# Altium schematic sheet WM_Communication.SchDoc
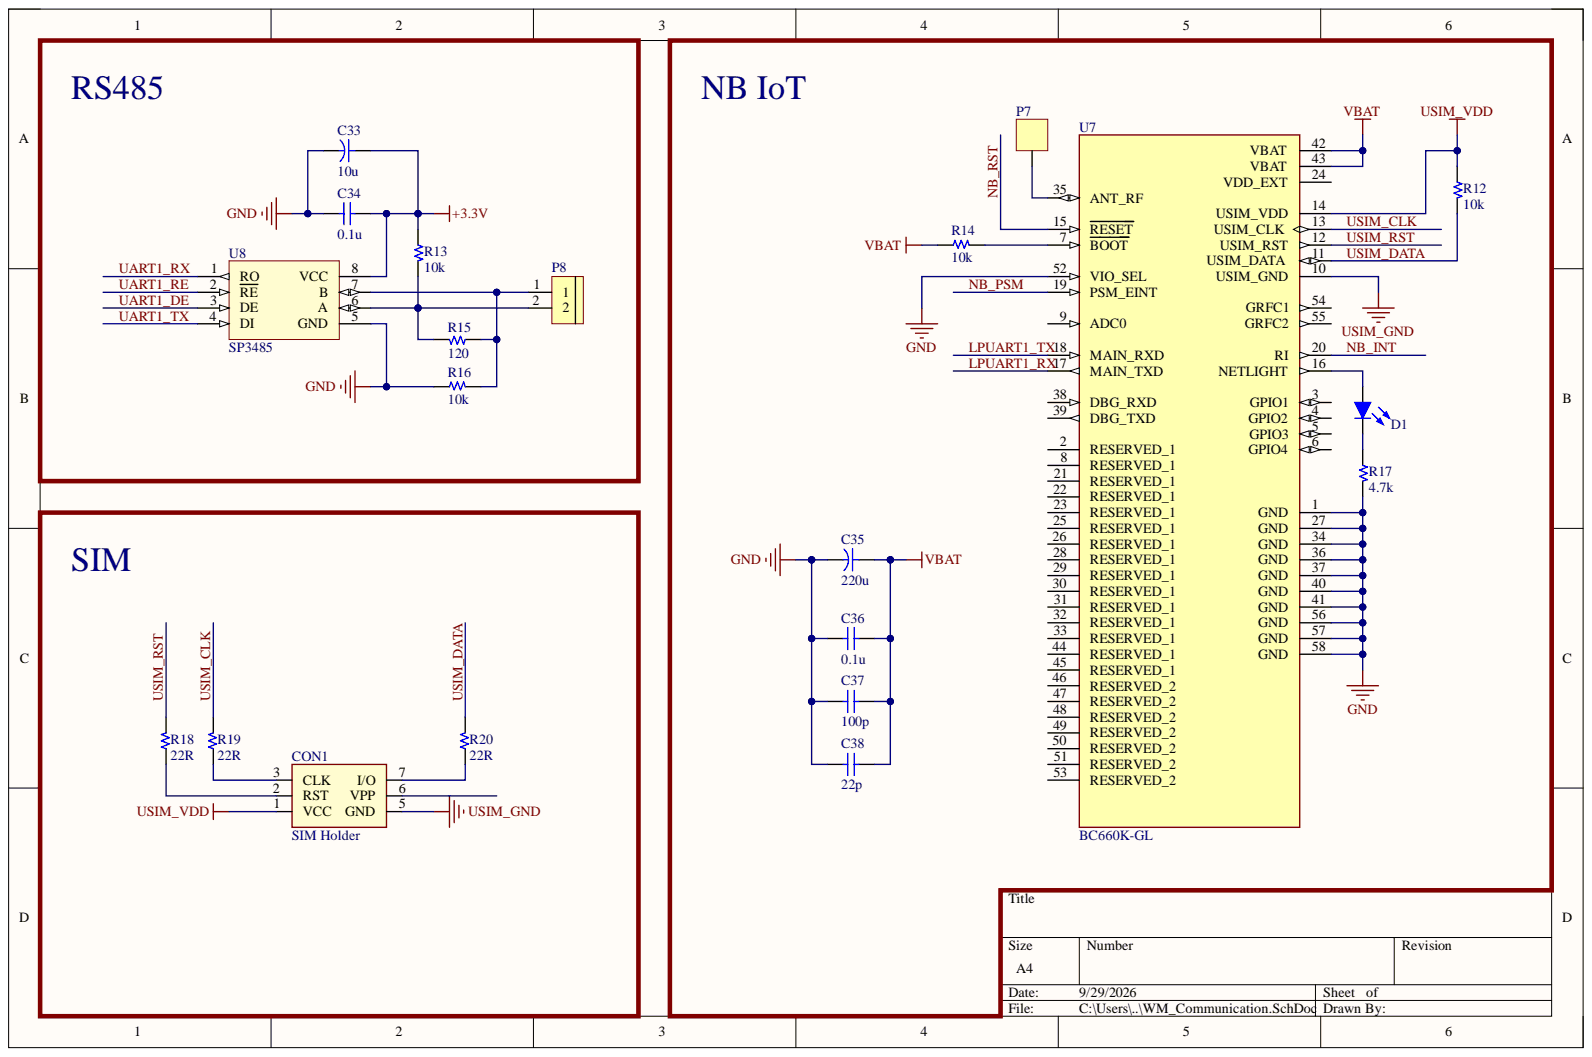
[diagram p0: WM_Communication.SchDoc]

## Parts
C33  10u  [C SMD ALU (B)]
C34  0.1u  [C 0603]
C35  220u  [C SMD ALU (D8)]
C36  0.1u  [C 0603]
C37  100p  [C 0603]
C38  22p  [C 0603]
CON1  SIM Holder  [CON SIM Micro 6P PUSH]
D1  Led  [Led 0603 - green]  (value not drawn)
P7  Header 1  [HDR/Header/1]  (value not drawn)
P8  2EDG5.08  [CON 2 2EDG5.08]  (value not drawn)
R12  10k  [R 0603]
R13  10k  [R 0603]
R14  10k  [R 0603]
R15  120  [R 0603]
R16  10k  [R 0603]
R17  4.7k  [R 0603]
R18  22R  [R 0603]
R19  22R  [R 0603]
R20  22R  [R 0603]
U7  BC660K-GL  [XCVR_BC660K-GL]
U8  SP3485  [SOIC-8]

## Nets
+3.3V: C33.1 C34.2 R13.1 U8.8(VCC)
GND: C33.2 C34.1 C35.2 C36.2 C37.2 C38.2 R16.1 R17.2 U7.1(GND) U7.27(GND) U7.34(GND) U7.36(GND) U7.37(GND) U7.40(GND) U7.41(GND) U7.52(VIO_SEL) U7.56(GND) U7.57(GND) U7.58(GND) U8.5(GND)
USIM_GND: CON1.5(GND) U7.10(USIM_GND)
USIM_VDD: CON1.1(VCC) R12.1 U7.14(USIM_VDD)
VBAT: C35.1 C36.1 C37.1 C38.1 R14.1 U7.42(VBAT) U7.43(VBAT)
LPUART1_RX: U7.17(MAIN_TXD)
LPUART1_TX: U7.18(MAIN_RXD)
NB_INT: U7.20(RI)
NB_PSM: U7.19(PSM_EINT)
NB_RST: U7.15(RESET)
UART1_DE: U8.3(DE)
UART1_RE: U8.2(RE)
UART1_RX: U8.1(RO)
UART1_TX: U8.4(DI)
USIM_CLK: R19.2 U7.13(USIM_CLK)
USIM_DATA: R12.2 R20.2 U7.11(USIM_DATA)
USIM_RST: R18.2 U7.12(USIM_RST)
NetCON1_2: CON1.2(RST) R18.1
NetCON1_3: CON1.3(CLK) R19.1
NetCON1_7: CON1.7(I/O) R20.1
NetD1_1: D1.1 U7.16(NETLIGHT)
NetD1_2: D1.2 R17.1
NetP7_1: P7.1 U7.35(ANT_RF)
NetP8_1: P8.1 R15.1 R16.2 U8.7(B)
NetP8_2: P8.2 R13.2 R15.2 U8.6(A)
NetR14_2: R14.2 U7.7(BOOT)

Not connected: CON1 6(VPP); U7 2(RESERVED_1) 3(GPIO1) 4(GPIO2) 5(GPIO3) 6(GPIO4) 8(RESERVED_1) 9(ADC0) 21(RESERVED_1) 22(RESERVED_1) 23(RESERVED_1) 24(VDD_EXT) 25(RESERVED_1) 26(RESERVED_1) 28(RESERVED_1) 29(RESERVED_1) 30(RESERVED_1) 31(RESERVED_1) 32(RESERVED_1) 33(RESERVED_1) 38(DBG_RXD) 39(DBG_TXD) 44(RESERVED_1) 45(RESERVED_1) 46(RESERVED_2) 47(RESERVED_2) 48(RESERVED_2) 49(RESERVED_2) 50(RESERVED_2) 51(RESERVED_2) 53(RESERVED_2) 54(GRFC1) 55(GRFC2)

## Library data of the parts
C33 = Capacitor: Aluminum electrolytic capacitor 16V 4x5.4mm; Component Kind: Standard; Library Name: chipfc_altium_libs.IntLib
C34 C36-C38 = Capacitor NP: Ceramic capacitor; Component Kind: Standard; Library Name: chipfc_altium_libs.IntLib
C35 = Capacitor: Aluminum electrolytic capacitor 16V 6.3x7.7mm; Component Kind: Standard; Library Name: chipfc_altium_libs.IntLib
CON1 = SIM holder 6 pin: SIM Card holder, 6 pins; Component Kind: Standard; Library Name: chipfc_altium_libs.IntLib
D1 = Led: Typical LED; Component Kind: Standard; Library Name: chipfc_altium_libs.IntLib
P7 = Header 1: Header, 1-Pin; Component Kind: Standard; Library Name: chipfc_altium_libs.IntLib
P8 = CON 2 EDG5.08: RS485; Chung_Loai: Cắm; Don_Vi: Con; Ma_Hang: MH000759; Ten_Linh_Kien: CON 2 2EDG5.08-2P 5.08MM ( Xanh Cong); Component Kind: Standard; Library Name: Altium_Lib_by_KhueNguyenCreator.IntLib
R12-R20 = Resistor: Component Kind: Standard; Description: Resistor; Library Name: chipfc_altium_libs.IntLib
U7 = BC660K-GL: Multi-band LTE Cat NB2 module; 17.7mm 15.8mm 2mm; 1.8g; SMT form factor; LCC package; Max. 127Kbps downlink / 158.5Kbps uplink; Extended temperature range of -40C to +85C.; MF: Quectel; Check_prices: https://www.snapeda.com/parts/BC660K-GL/Quectel/view-part/?ref=eda; Component Kind: Standard; Library Name: BC660K-GL.IntLib; MP: BC660K-GL; Package: None; SnapEDA_Link: https://www.snapeda.com/parts/BC660K-GL/Quectel/view-part/?ref=snap
U8 = MAX485: Low-Power, Slew-Rate-Limited  RS-485/RS-422 Transceivers; Component Kind: Standard; Library Name: chipfc_altium_libs.IntLib
